# Altium schematic sheet 01_ToF.SchDoc
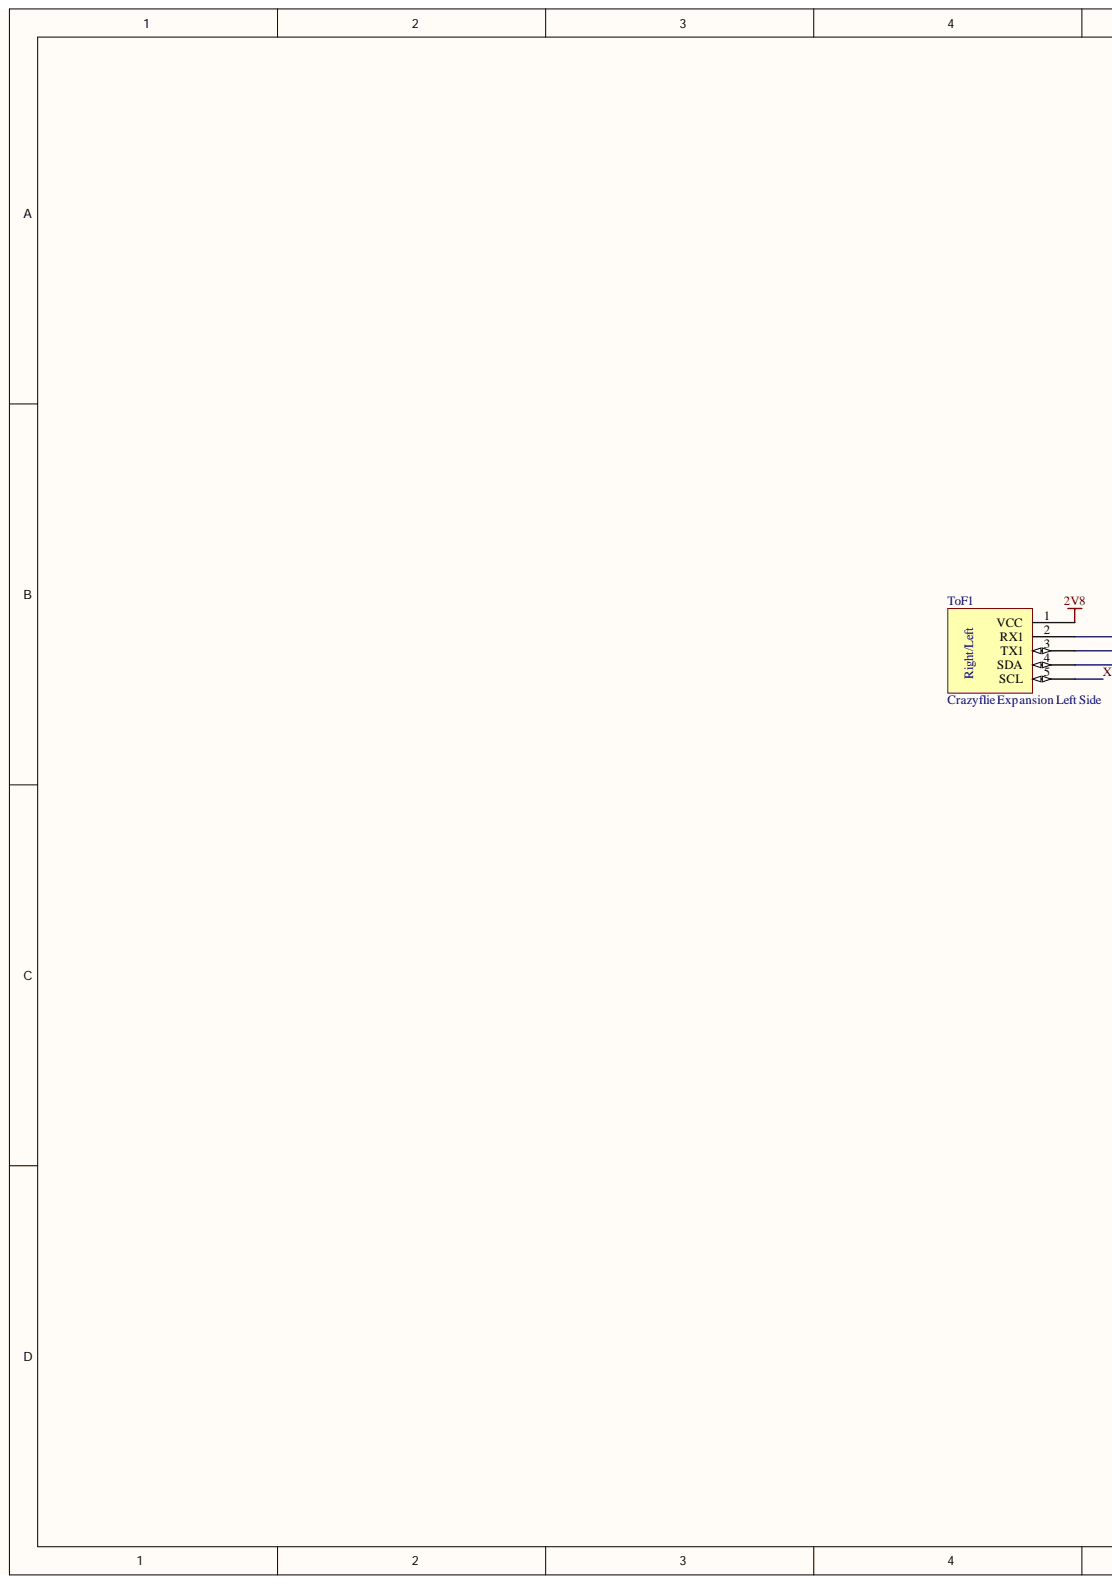
[diagram p0: 01_ToF.SchDoc, left]
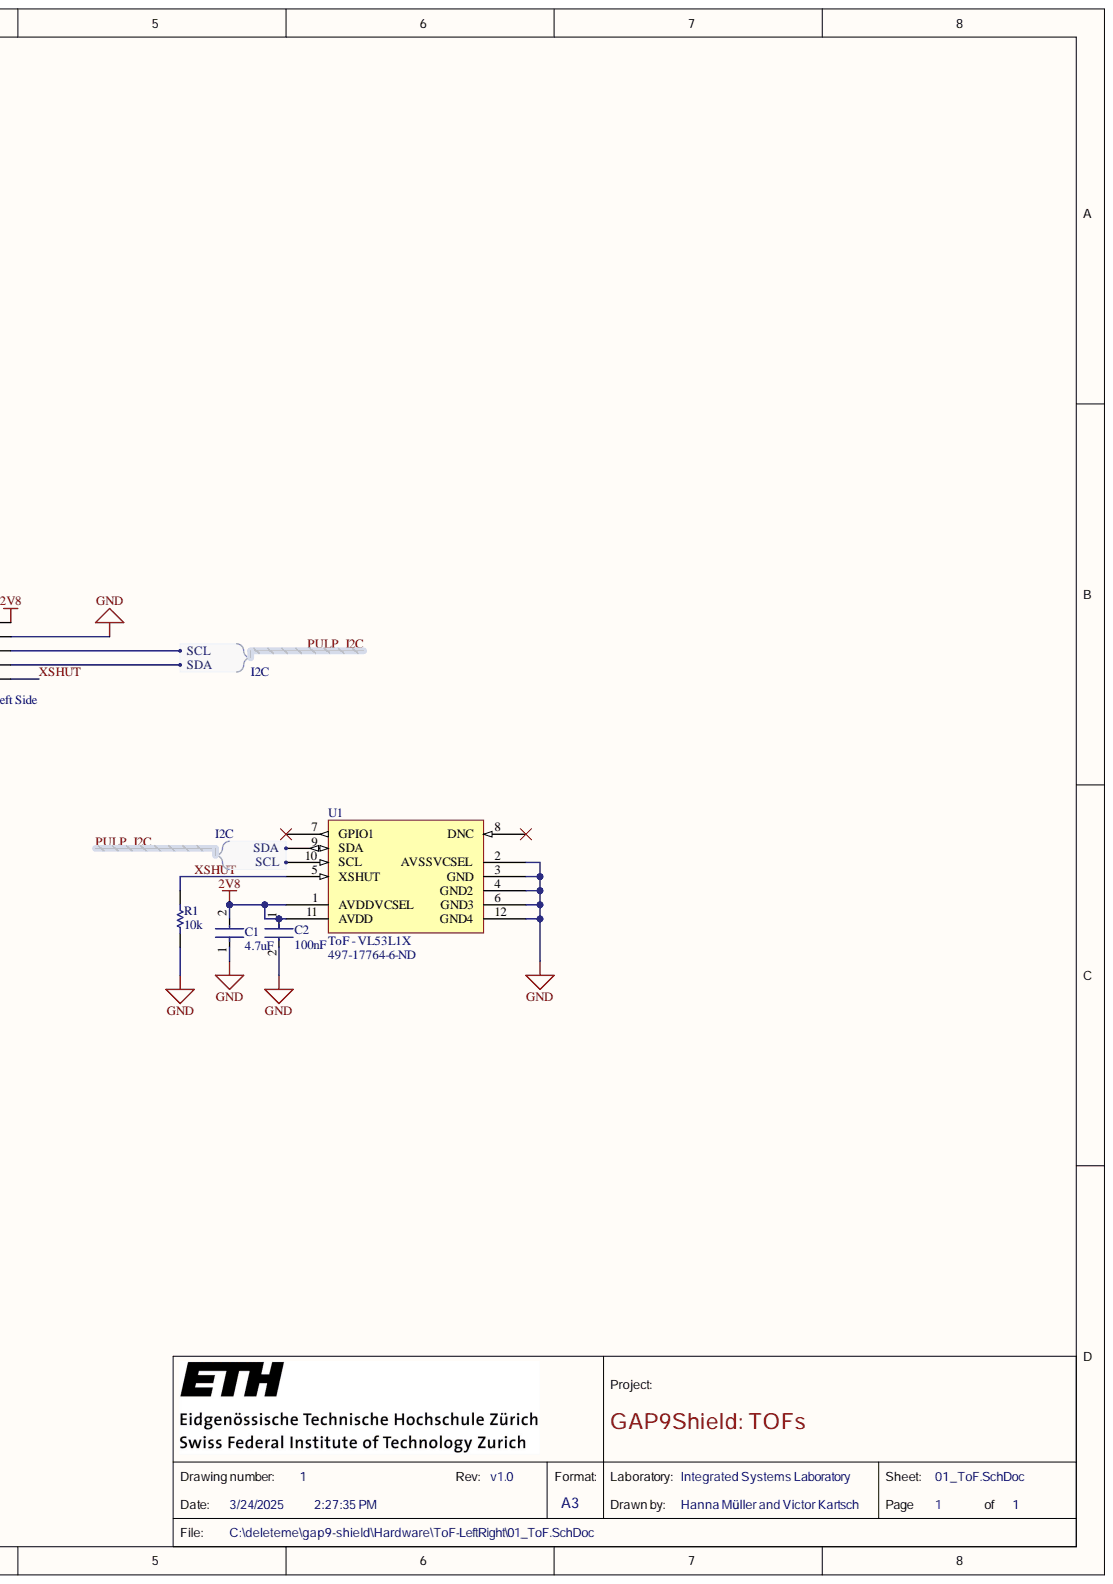
[diagram p1: 01_ToF.SchDoc, right]

## Parts
C1  4.7uF  [FP-GRM035-0_09-IPC_B]
C2  100nF  [FP-C0603-030-0_03-IPC_C]
R1  value 10k  [RESC0603X28X15LL05T05]  (hidden comment: CRCW020110K0FNED)
ToF1  Crazyflie Expansion Left Side  [ToF_Right_Left]
U1  ToF - VL53L1X  Supplier Part Number 1: 497-17764-6-ND  [TOF]

## Nets
2V8: C1.2 C2.1 ToF1.1(VCC) U1.1(AVDDVCSEL) U1.11(AVDD)
GND: C1.1 C2.2 R1.2 ToF1.2(RX1) U1.2(AVSSVCSEL) U1.3(GND) U1.4(GND2) U1.6(GND3) U1.12(GND4)
PULP_I2C.SCL: ToF1.3(TX1) U1.10(SCL)
PULP_I2C.SDA: ToF1.4(SDA) U1.9(SDA)
XSHUT: R1.1 ToF1.5(SCL) U1.5(XSHUT)

Not connected: U1 7(GPIO1) 8(DNC)

## Library data of the parts
C1 = CMP-06035-019701-1: Chip Multilayer Ceramic Capacitors for General Purpose, 0201, 4.7uF, X5R, 15%, 20%, 6.3V; Capacitance: 4.7uF; Case Code (Imperial): 0201; Case Code (Metric): 0603; Case/Package: 0201; Component Kind: Standard; Dielectric: X5R; Length: 0.024inch; Library Name: Altium Content Vault; Max Operating Temperature: 85°C; Min Operating Temperature: -55°C; Mount: Surface Mount; Packaging: Tape and Reel; Thickness: 0.022inch; Tolerance: 20%; Voltage Rating: 6.3V; Voltage Rating (DC): 6.3V; Width: 0.012inch
C2 = CMP-08246-005139-1: Multilayer Ceramic Capacitors 100nF ±10% 25V X5R SMD 0201; Capacitance: 100nF; Case Code (Imperial): 0201; Case Code (Metric): 0603; Case/Package: 0201; Component Kind: Standard; Depth: 0.3mm; Dielectric: X5R; Dielectric Material: Ceramic; Height: 0.3mm; Lead Free: Lead Free; Length: 0.6mm; Library Name: Altium Content Vault; Max Operating Temperature: 85°C; Min Operating Temperature: -55°C; Mount: Surface Mount; Package Quantity: 15000; Packaging: Tape and Reel; REACH SVHC: No SVHC; RoHS Compliant: Yes; Series: C; Termination: SMD/SMT; Thickness: 0.013inch; Tolerance: 10%; Value: 100nF; Voltage Rating: 25V; Voltage Rating (DC): 25V; Weight: 6E-06oz; Width: 0.012inch
R1 = CMP-2004-00016-1: Manufacturer: Vishay Dale; Component Kind: Standard; Library Name: Altium Content Vault; Part Number: CRCW020110K0FNED
ToF1 = ToF_Right_Letf: Component Kind: Standard; Supplier 1: Digi-Key; Supplier Part Number 1: 110990442-ND; Library Name: gap9_deck.SCHLIB
U1 = VL53L1X: Manufacturer: STMicroelectronics; Manufacturer Part Number: VL53L1CXV0FY/1; Component Kind: Standard; ComponentLink1Description: http://www.farnell.com/datasheets/2600105.pdf?src-supplier=Farnell; ComponentLink1URL: http://www.farnell.com/datasheets/2600105.pdf?src-supplier=Farnell; ComponentLink2Description: http://www.st.com/content/ccc/resource/technical/document/datasheet/group3/7d/85/c8/95/fb/3b/4e/2d/DM00452094/files/DM00452094.pdf/jcr:content/translations/en.DM00452094.pdf?src-supplier=Digi-Key; ComponentLink2URL: http://www.st.com/content/ccc/resource/technical/document/datasheet/group3/7d/85/c8/95/fb/3b/4e/2d/DM00452094/files/DM00452094.pdf/jcr:content/translations/en.DM00452094.pdf?src-supplier=Digi-Key; Pricing 1: 1 = 7.07000, 10 = 6.38600, 100 = 5.28660, 500 = 4.60350, 1000 = 4.00950 (USD); RoHS: No; Stock 1: 5939; Supplier 1: Digi-Key; Library Name: Pulp_Shield_wolf.SCHLIB
